# Altium schematic sheet LM386.SchDoc
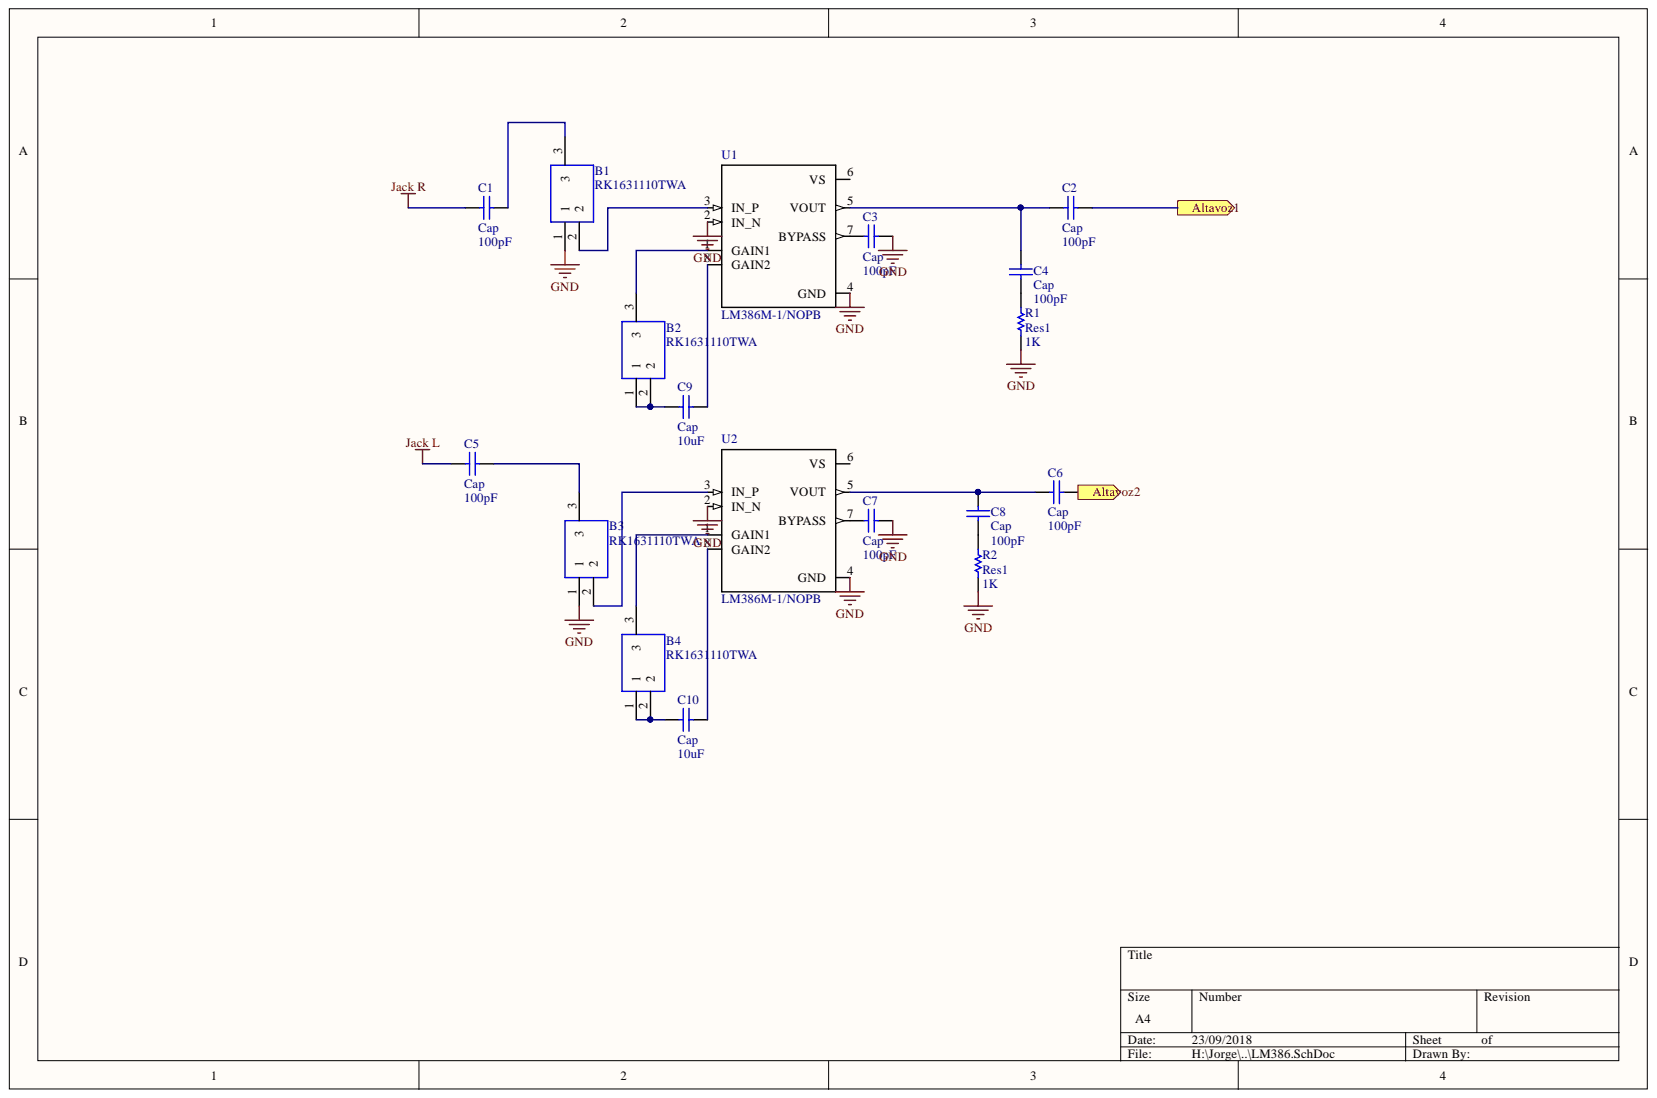
[diagram p0: LM386.SchDoc]

## Parts
B1  RK1631110TWA  [RK1631110TWA]
B2  RK1631110TWA  [RK1631110TWA]
B3  RK1631110TWA  [RK1631110TWA]
B4  RK1631110TWA  [RK1631110TWA]
C1  Cap  value 100pF  [RAD-0.3]
C2  Cap  value 100pF  [RAD-0.3]
C3  Cap  value 100pF  [RAD-0.3]
C4  Cap  value 100pF  [RAD-0.3]
C5  Cap  value 100pF  [RAD-0.3]
C6  Cap  value 100pF  [RAD-0.3]
C7  Cap  value 100pF  [RAD-0.3]
C8  Cap  value 100pF  [RAD-0.3]
C9  Cap  value 10uF  [RAD-0.3]
C10  Cap  value 10uF  [RAD-0.3]
R1  Res1  value 1K  [AXIAL-0.3]
R2  Res1  value 1K  [AXIAL-0.3]
U1  LM386M-1/NOPB  [SOIC127P600X175-8N]
U2  LM386M-1/NOPB  [SOIC127P600X175-8N]

## Ports
Altavoz1, Altavoz2

## Nets
GND: B1.1 B3.1 C3.1 C7.2 R1.1 R2.1 U1.2(IN_N) U1.4(GND) U2.2(IN_N) U2.4(GND)
Jack L: C5.2
Jack R: C1.2
Altavoz1: C2.2 ; port Altavoz1
Altavoz2: C6.2 ; port Altavoz2
NetB1_2: B1.2 U1.3(IN_P)
NetB1_3: B1.3 C1.1
NetB2_1: B2.1 B2.2 C9.2
NetB2_3: B2.3 U1.1(GAIN1)
NetB3_2: B3.2 U2.3(IN_P)
NetB3_3: B3.3 C5.1
NetB4_1: B4.1 B4.2 C10.2
NetB4_3: B4.3 U2.1(GAIN1)
NetC2_1: C2.1 C4.2 U1.5(VOUT)
NetC3_2: C3.2 U1.7(BYPASS)
NetC4_1: C4.1 R1.2
NetC6_1: C6.1 C8.2 U2.5(VOUT)
NetC7_1: C7.1 U2.7(BYPASS)
NetC8_1: C8.1 R2.2
NetC9_1: C9.1 U1.8(GAIN2)
NetC10_1: C10.1 U2.8(GAIN2)

Not connected: U1 6(VS); U2 6(VS)

## Library data of the parts
B1-B4 = RK1631110TWA: Carbon Film Potentiometer RK163 Series with a 6 mm Dia. Shaft 10k 20% 0.1W; Manufacturer_Name: ALPS; Arrow Part Number: RK1631110TWA; Arrow Price/Stock: http://uk.rs-online.com/web/p/products/1726361; Component Kind: Standard; Datasheet Link: http://docs-europe.electrocomponents.com/webdocs/135d/0900766b8135daa5.pdf; Library Name: RK1631110TWA.SchLib; Manufacturer_Part_Number: RK1631110TWA; RS Part Number: 1726361; RS Price/Stock: http://uk.rs-online.com/web/p/products/1726361
C1 C2 C4-C6 C8 C10 = Cap: Capacitor; Component Kind: Standard; LatestRevisionDate: 17-Jul-2002; LatestRevisionNote: Re-released for DXP Platform.; Library Name: Miscellaneous Devices.IntLib; PackageDescription: Radial Cap, Thru-Hole; 2 Leads; 0.3 in Pin Spacing; PackageReference: RAD-0.3; Published: 8-Jun-2000; Signal Integrity: Cap; Simulation: CAP; Publisher: Altium Limited
C3 C7 = Cap: Capacitor; Value: 100pF; Component Kind: Standard; LatestRevisionDate: 17-Jul-2002; LatestRevisionNote: Re-released for DXP Platform.; Library Name: Miscellaneous Devices.IntLib; PackageDescription: Radial Cap, Thru-Hole; 2 Leads; 0.3 in Pin Spacing; PackageReference: RAD-0.3; Published: 8-Jun-2000; Signal Integrity: Cap; Simulation: CAP; Publisher: Altium Limited
C9 = Cap: Capacitor; Value: 10uF; Component Kind: Standard; LatestRevisionDate: 17-Jul-2002; LatestRevisionNote: Re-released for DXP Platform.; Library Name: Miscellaneous Devices.IntLib; PackageDescription: Radial Cap, Thru-Hole; 2 Leads; 0.3 in Pin Spacing; PackageReference: RAD-0.3; Published: 8-Jun-2000; Signal Integrity: Cap; Simulation: CAP; Publisher: Altium Limited
R1 R2 = Res1: Resistor; Component Kind: Standard; LatestRevisionDate: 17-Jul-2002; LatestRevisionNote: Re-released for DXP Platform.; Library Name: Miscellaneous Devices.IntLib; PackageDescription: Axial Device, Thru-Hole; 2 Leads; 0.3 in Pin Spacing; PackageReference: AXIAL-0.3; Published: 8-Jun-2000; Signal Integrity: Res; Simulation: RESISTOR; Publisher: Altium Limited
U1 U2 = LM386M-1/NOPB: Wide Input Voltage Low Power Audio Amplifier with Internal Gain 8-SOIC 0 to 70; MF: Texas Instruments; Availability: Good; Component Kind: Standard; Library Name: LM386M-1_NOPB.SchLib; MP: LM386M-1; Package: SOIC-8 Texas Instruments; Price: 0.76 USD; SnapEDA_Link: https://www.snapeda.com/parts/LM386M-1/Texas%20Instruments/view-part/?ref=snap
